# Altium schematic sheet Connectors.SchDoc(Connectors)
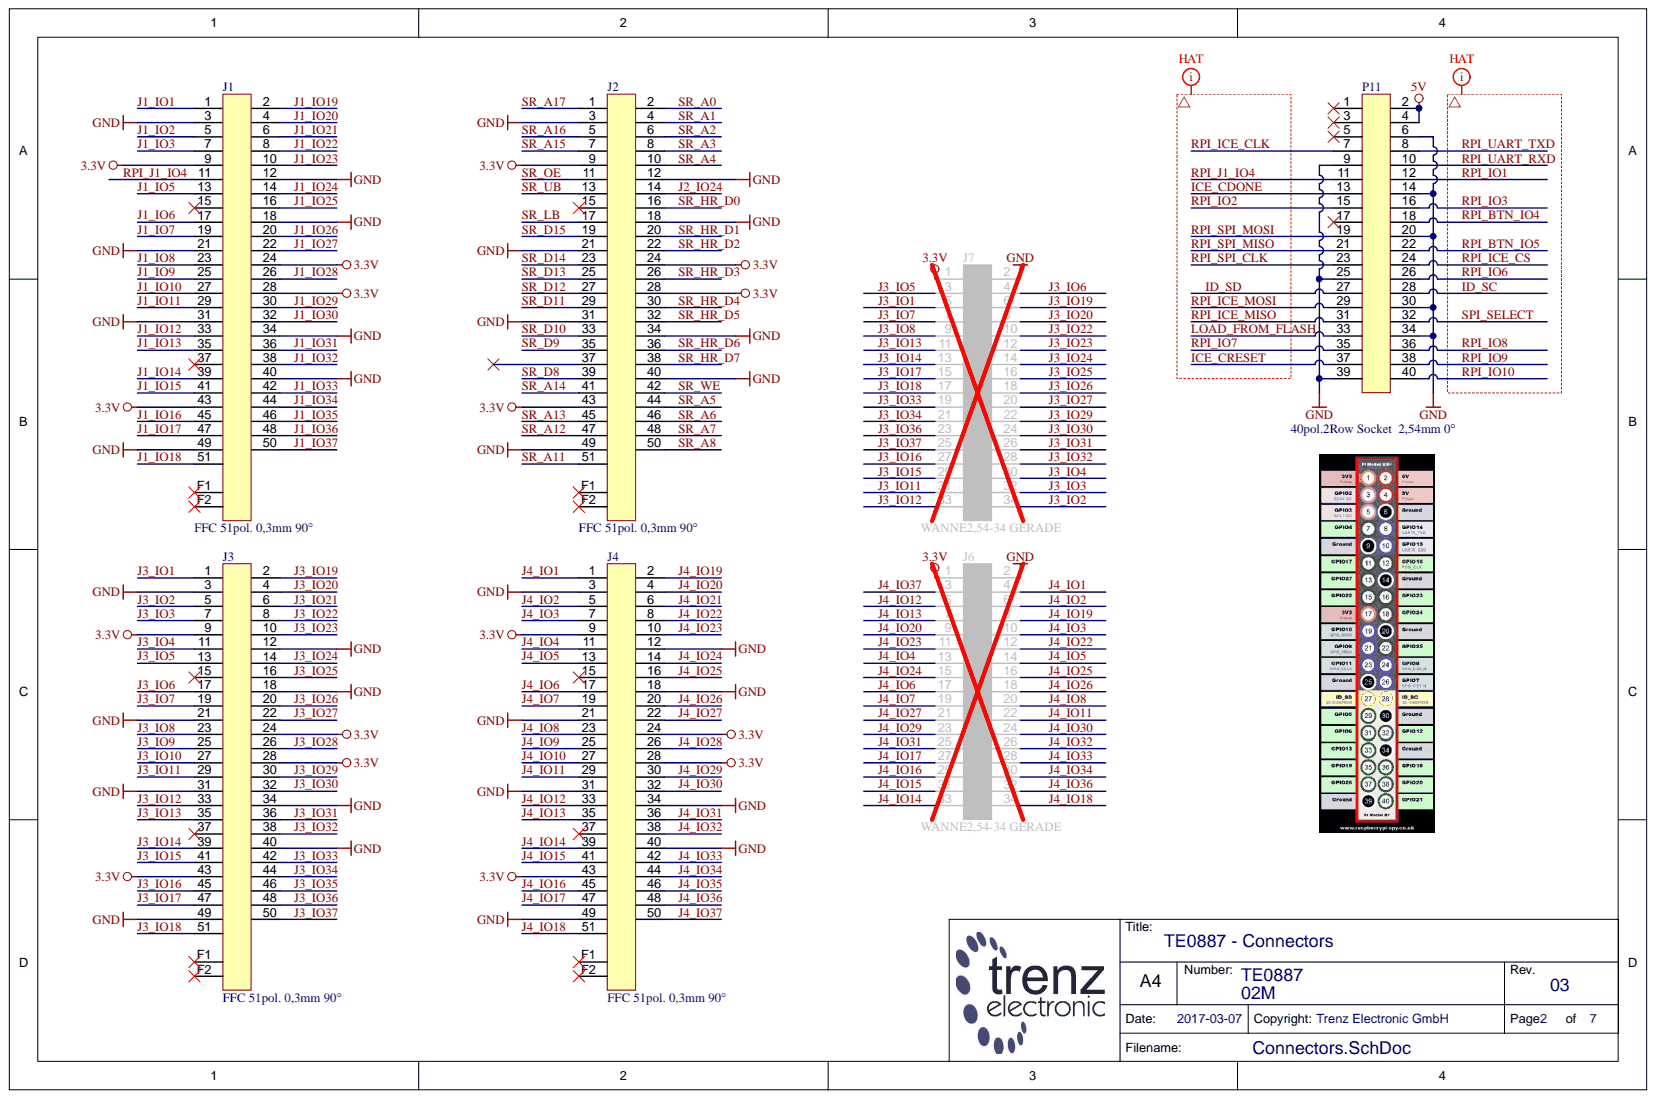
[diagram p0: Connectors.SchDoc]

## Parts
J1  FFC 51pol. 0,3mm 90°  [5-2041390-1]
J2  FFC 51pol. 0,3mm 90°  [5-2041390-1]
J3  FFC 51pol. 0,3mm 90°  [5-2041390-1]
J4  FFC 51pol. 0,3mm 90°  [5-2041390-1]
J6  WANNE2,54-34 GERADE  [WANNE2,54-34 GERADE]
J7  WANNE2,54-34 GERADE  [WANNE2,54-34 GERADE]
P11  40pol.2Row Socket  2,54mm 0°  [NPTC202KFMS-RC]

## Nets
3.3V: J1.9 J1.24 J1.28 J1.43 J2.9 J2.24 J2.28 J2.43 J3.9 J3.24 J3.28 J3.43 J4.9 J4.24 J4.28 J4.43 J6.1 J7.1
5V: P11.2 P11.4
GND: J1.3 J1.12 J1.18 J1.21 J1.31 J1.34 J1.40 J1.49 J2.3 J2.12 J2.18 J2.21 J2.31 J2.34 J2.40 J2.49 J3.3 J3.12 J3.18 J3.21 J3.31 J3.34 J3.40 J3.49 J4.3 J4.12 J4.18 J4.21 J4.31 J4.34 J4.40 J4.49 J6.2 J7.2 P11.6 P11.9 P11.14 P11.20 P11.25 P11.30 P11.34 P11.39
ICE_CDONE: P11.13
ICE_CRESET: P11.37
ID_SC: P11.28
ID_SD: P11.27
J1_IO1: J1.1
J1_IO2: J1.5
J1_IO3: J1.7
J1_IO5: J1.13
J1_IO6: J1.17
J1_IO7: J1.19
J1_IO8: J1.23
J1_IO9: J1.25
J1_IO10: J1.27
J1_IO11: J1.29
J1_IO12: J1.33
J1_IO13: J1.35
J1_IO14: J1.39
J1_IO15: J1.41
J1_IO16: J1.45
J1_IO17: J1.47
J1_IO18: J1.51
J1_IO19: J1.2
J1_IO20: J1.4
J1_IO21: J1.6
J1_IO22: J1.8
J1_IO23: J1.10
J1_IO24: J1.14
J1_IO25: J1.16
J1_IO26: J1.20
J1_IO27: J1.22
J1_IO28: J1.26
J1_IO29: J1.30
J1_IO30: J1.32
J1_IO31: J1.36
J1_IO32: J1.38
J1_IO33: J1.42
J1_IO34: J1.44
J1_IO35: J1.46
J1_IO36: J1.48
J1_IO37: J1.50
J2_IO24: J2.14
J3_IO1: J3.1 J7.5
J3_IO2: J3.5 J7.34
J3_IO3: J3.7 J7.32
J3_IO4: J3.11 J7.30
J3_IO5: J3.13 J7.3
J3_IO6: J3.17 J7.4
J3_IO7: J3.19 J7.7
J3_IO8: J3.23 J7.9
J3_IO9: J3.25
J3_IO10: J3.27
J3_IO11: J3.29 J7.31
J3_IO12: J3.33 J7.33
J3_IO13: J3.35 J7.11
J3_IO14: J3.39 J7.13
J3_IO15: J3.41 J7.29
J3_IO16: J3.45 J7.27
J3_IO17: J3.47 J7.15
J3_IO18: J3.51 J7.17
J3_IO19: J3.2 J7.6
J3_IO20: J3.4 J7.8
J3_IO21: J3.6
J3_IO22: J3.8 J7.10
J3_IO23: J3.10 J7.12
J3_IO24: J3.14 J7.14
J3_IO25: J3.16 J7.16
J3_IO26: J3.20 J7.18
J3_IO27: J3.22 J7.20
J3_IO28: J3.26
J3_IO29: J3.30 J7.22
J3_IO30: J3.32 J7.24
J3_IO31: J3.36 J7.26
J3_IO32: J3.38 J7.28
J3_IO33: J3.42 J7.19
J3_IO34: J3.44 J7.21
J3_IO35: J3.46
J3_IO36: J3.48 J7.23
J3_IO37: J3.50 J7.25
J4_IO1: J4.1 J6.4
J4_IO2: J4.5 J6.6
J4_IO3: J4.7 J6.10
J4_IO4: J4.11 J6.13
J4_IO5: J4.13 J6.14
J4_IO6: J4.17 J6.17
J4_IO7: J4.19 J6.19
J4_IO8: J4.23 J6.20
J4_IO9: J4.25
J4_IO10: J4.27
J4_IO11: J4.29 J6.22
J4_IO12: J4.33 J6.5
J4_IO13: J4.35 J6.7
J4_IO14: J4.39 J6.33
J4_IO15: J4.41 J6.31
J4_IO16: J4.45 J6.29
J4_IO17: J4.47 J6.27
J4_IO18: J4.51 J6.34
J4_IO19: J4.2 J6.8
J4_IO20: J4.4 J6.9
J4_IO21: J4.6
J4_IO22: J4.8 J6.12
J4_IO23: J4.10 J6.11
J4_IO24: J4.14 J6.15
J4_IO25: J4.16 J6.16
J4_IO26: J4.20 J6.18
J4_IO27: J4.22 J6.21
J4_IO28: J4.26
J4_IO29: J4.30 J6.23
J4_IO30: J4.32 J6.24
J4_IO31: J4.36 J6.25
J4_IO32: J4.38 J6.26
J4_IO33: J4.42 J6.28
J4_IO34: J4.44 J6.30
J4_IO35: J4.46
J4_IO36: J4.48 J6.32
J4_IO37: J4.50 J6.3
LOAD_FROM_FLASH: P11.33
RPI_BTN_IO4: P11.18
RPI_BTN_IO5: P11.22
RPI_ICE_CLK: P11.7
RPI_ICE_CS: P11.24
RPI_ICE_MISO: P11.31
RPI_ICE_MOSI: P11.29
RPI_IO1: P11.12
RPI_IO2: P11.15
RPI_IO3: P11.16
RPI_IO6: P11.26
RPI_IO7: P11.35
RPI_IO8: P11.36
RPI_IO9: P11.38
RPI_IO10: P11.40
RPI_J1_IO4: J1.11 P11.11
RPI_SPI_CLK: P11.23
RPI_SPI_MISO: P11.21
RPI_SPI_MOSI: P11.19
RPI_UART_RXD: P11.10
RPI_UART_TXD: P11.8
SPI_SELECT: P11.32
SR_A0: J2.2
SR_A1: J2.4
SR_A2: J2.6
SR_A3: J2.8
SR_A4: J2.10
SR_A5: J2.44
SR_A6: J2.46
SR_A7: J2.48
SR_A8: J2.50
SR_A11: J2.51
SR_A12: J2.47
SR_A13: J2.45
SR_A14: J2.41
SR_A15: J2.7
SR_A16: J2.5
SR_A17: J2.1
SR_D8: J2.39
SR_D9: J2.35
SR_D10: J2.33
SR_D11: J2.29
SR_D12: J2.27
SR_D13: J2.25
SR_D14: J2.23
SR_D15: J2.19
SR_HR_D0: J2.16
SR_HR_D1: J2.20
SR_HR_D2: J2.22
SR_HR_D3: J2.26
SR_HR_D4: J2.30
SR_HR_D5: J2.32
SR_HR_D6: J2.36
SR_HR_D7: J2.38
SR_LB: J2.17
SR_OE: J2.11
SR_UB: J2.13
SR_WE: J2.42
N00120:
N00121:

Not connected: J1 15 37 F1 F2; J2 15 37 F1 F2; J3 15 37 F1 F2; J4 15 37 F1 F2; P11 1 3 5 17

## Library data of the parts
J1-J4 = 27147: FFC 51pol. 0.3mm 90°; Database Table Name: CON_IO; Mixed: SMT; AN: 27147; Component Kind: Standard; H-ART: 5-2041390-1; Library Name: TE.DbLib; HelpURL: B:\ad\adlib\datasheet\5-2041390-1
J6 J7 = 20129: Stiftleiste Wanne 34polig; Database Table Name: CON_HEADER; Mixed: THT; Trenz-Stock: Yes; AN: 20129; Component Kind: Standard; Library Name: TE.DbLib; HelpURL: B:\AD\adlib\datasheet\137
P11 = 27148: 40pol.2r. 2,54mm 0° Socket; Database Table Name: CON_IO; Mixed: SMT; AN: 27148; Component Kind: Standard; H-ART: NPTC202KFMS-RC; Library Name: TE.DbLib; HelpURL: B:\ad\adlib\datasheet\NPTC202KFMS-RC
